# Altium schematic sheet Sheet1.SchDoc
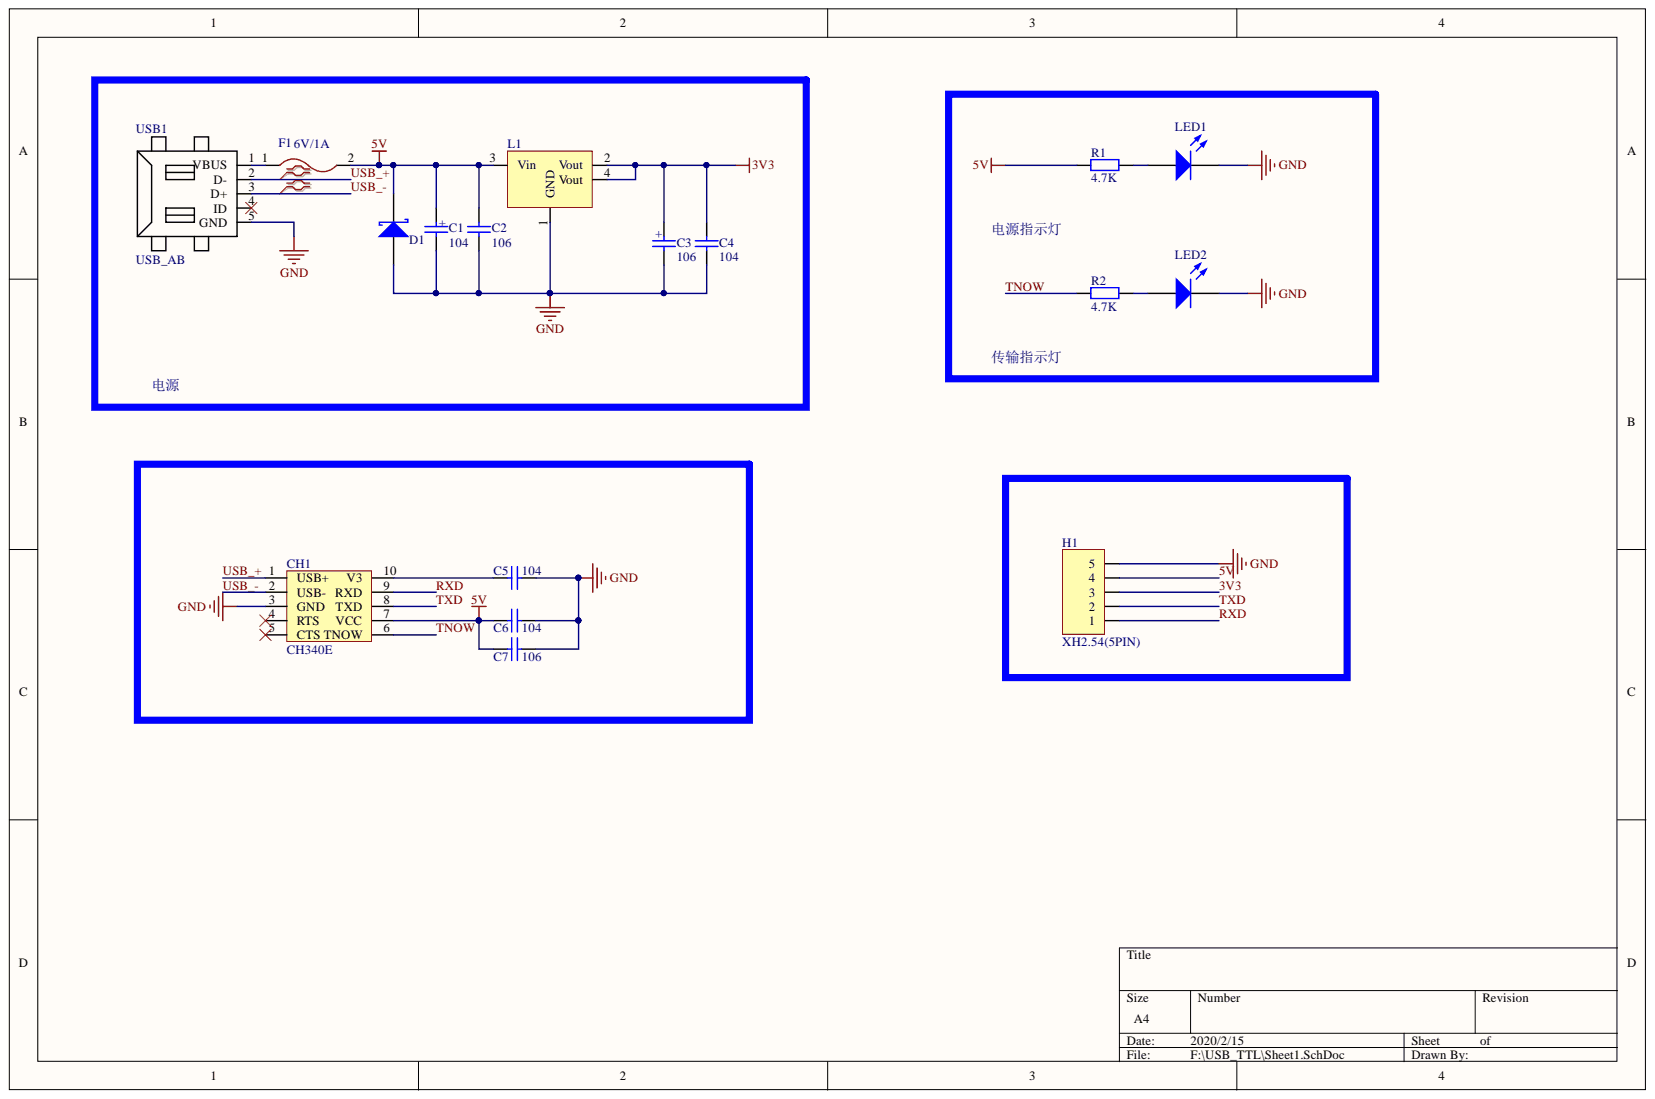
[diagram p0: Sheet1.SchDoc]

## Parts
C1  104  [C_SOP_0603]
C2  106  [C_SOP_0603]
C3  106  [C_SOP_0603]
C4  104  [C_SOP_0603]
C5  104  [C_SOP_0603]
C6  104  [C_SOP_0603]
C7  106  [C_SOP_0603]
CH1  CH340E  [MSOP_10]
D1  DIODE  [DIODE_1206]  (value not drawn)
F1  6V/1A  [Fuse_0805]
H1  XH2.54(5PIN)  [XH_2.54_5P_H]
L1  LDO-3.3-AMS1117  [SOT223_3]  (value not drawn)
LED1  LED  [LED_0805_R]
LED2  LED  [LED_0805_R]
R1  4.7K  [R_SMD_0603]
R2  4.7K  [R_SMD_0603]
USB1  USB_AB  [USB_MINI_SMD]

## Nets
GND: C1.1 C2.1 C3.2 C4.2 C5.2 C6.2 C7.2 CH1.3(GND) D1.2 H1.5 L1.1(GND) LED1.2 LED2.2 USB1.5(GND)
3V3: C3.1 C4.1 H1.3 L1.2(Vout) L1.4(Vout)
5V: C1.2 C2.2 C6.1 C7.1 CH1.7(VCC) D1.1 F1.2 H1.4 L1.3(Vin) R1.1
RXD: CH1.9(RXD) H1.1
TNOW: CH1.6(TNOW) R2.1
TXD: CH1.8(TXD) H1.2
USB_+: CH1.1(USB+) USB1.2(D-)
USB_-: CH1.2(USB-) USB1.3(D+)
NetC5_1: C5.1 CH1.10(V3)
NetF1_1: F1.1 USB1.1(VBUS)
NetLED1_1: LED1.1 R1.2
NetLED2_1: LED2.1 R2.2

Not connected: CH1 4(RTS) 5(CTS); USB1 4(ID)

## Library data of the parts
C1-C7 = C_SMD: Component Kind: Standard; Library Name: DG.SchLib
F1 = FUSE: Component Kind: Standard; Library Name: DG.SchLib
R1 R2 = R_SMD: Component Kind: Standard; Library Name: DG.SchLib
CH1 = CH340E: Component Kind: Standard; Library Name: DG.SchLib
D1 = DIODE: Component Kind: Standard; Library Name: DG.SchLib
H1 = XH2.54(5PIN): Component Kind: Standard; Library Name: DG.SchLib
L1 = LDO-3.3-AMS1117: Component Kind: Standard; Library Name: DG.SchLib
LED1 LED2 = LED: Component Kind: Standard; Library Name: DG.SchLib
USB1 = USB_AB: Component Kind: Standard; Library Name: DG.SchLib
